# Altium schematic sheet ADC_MCU_LS.SchDoc(ADC_MCU_LS)
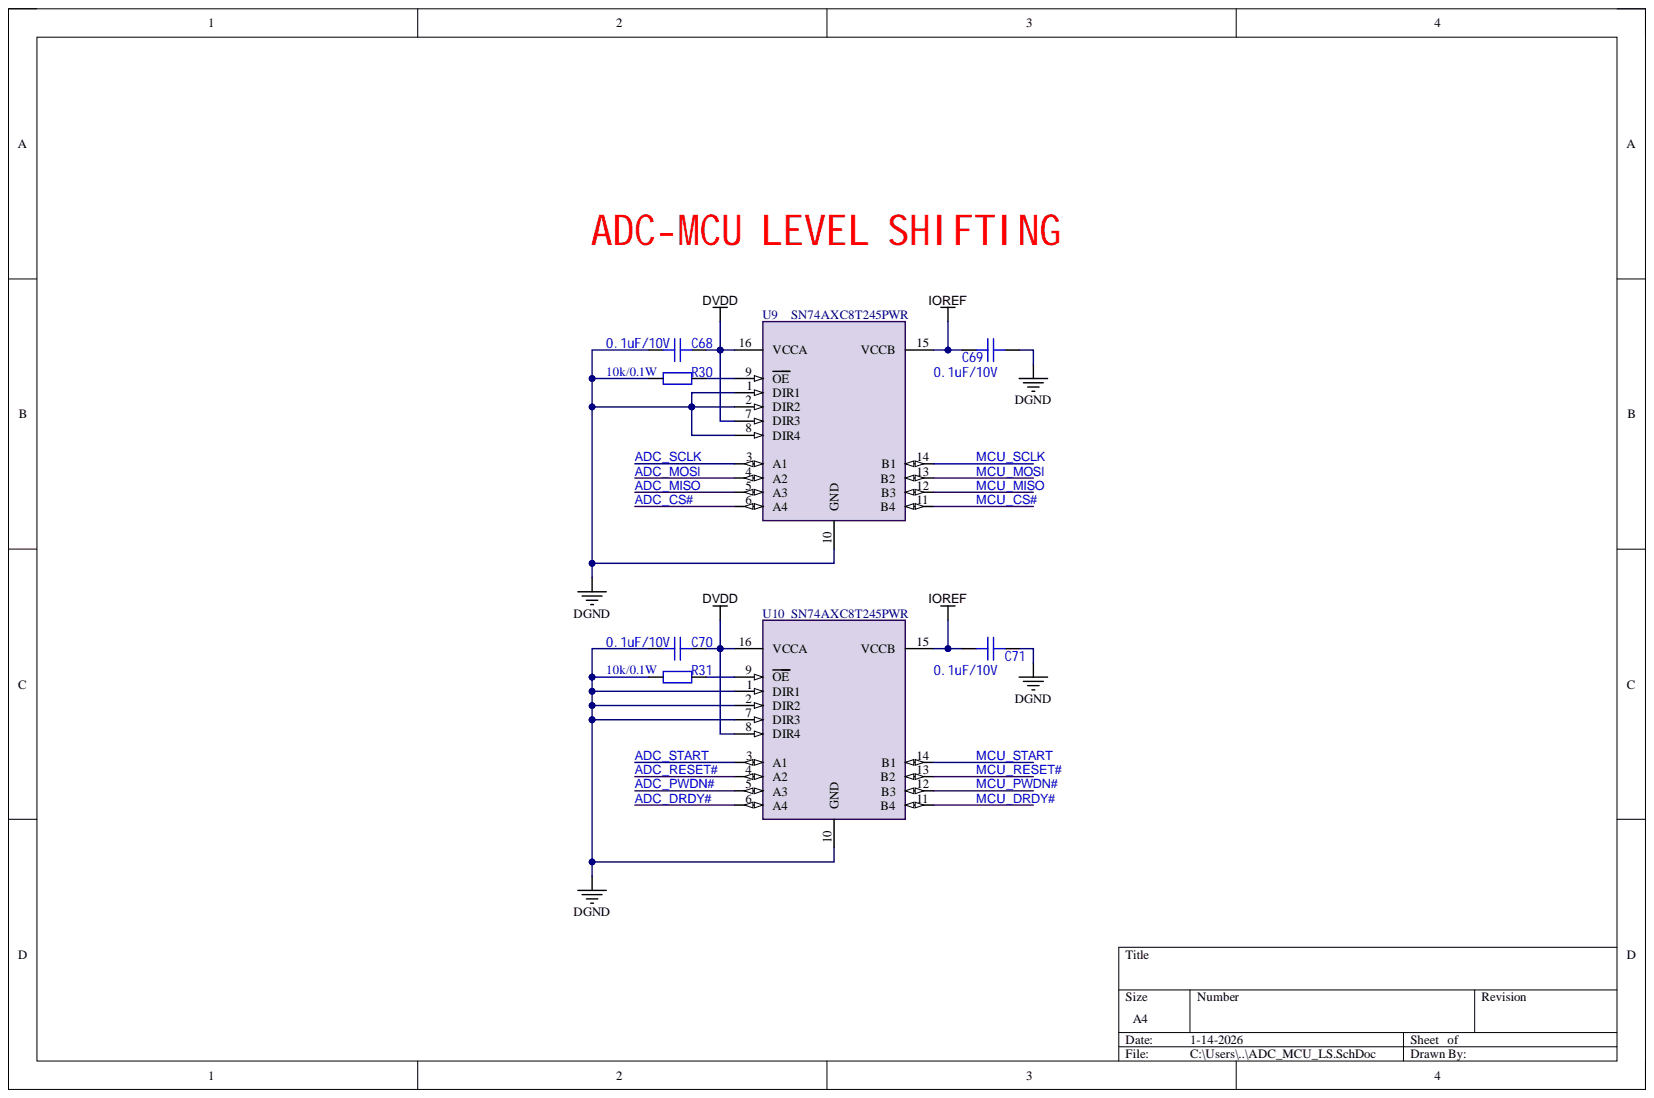
[diagram p0: ADC_MCU_LS.SchDoc]

## Parts
C68  0.1uF  value 0.1uF/10V  [C0603]
C69  0.1uF  value 0.1uF/10V  [C0603]
C70  0.1uF  value 0.1uF/10V  [C0603]
C71  0.1uF  value 0.1uF/10V  [C0603]
R30  value 10k/0.1W  [R0603]  (hidden comment: 10K)
R31  value 10k/0.1W  [R0603]  (hidden comment: 10K)
U9  SN74AXC8T245PWR  [SOP65P640X120-16N]
U10  SN74AXC8T245PWR  [SOP65P640X120-16N]

## Nets
DGND: C68.2 C69.1 C70.2 C71.1 R30.1 R31.1 U9.1(DIR1) U9.2(DIR2) U9.8(DIR4) U9.10(GND) U10.1(DIR1) U10.2(DIR2) U10.7(DIR3) U10.10(GND)
DVDD: C68.1 C70.1 U9.7(DIR3) U9.16(VCCA) U10.8(DIR4) U10.16(VCCA)
IOREF: C69.2 C71.2 U9.15(VCCB) U10.15(VCCB)
ADC_CS#: U9.6(A4)
ADC_DRDY#: U10.6(A4)
ADC_MISO: U9.5(A3)
ADC_MOSI: U9.4(A2)
ADC_PWDN#: U10.5(A3)
ADC_RESET#: U10.4(A2)
ADC_SCLK: U9.3(A1)
ADC_START: U10.3(A1)
MCU_CS#: U9.11(B4)
MCU_DRDY#: U10.11(B4)
MCU_MISO: U9.12(B3)
MCU_MOSI: U9.13(B2)
MCU_PWDN#: U10.12(B3)
MCU_RESET#: U10.13(B2)
MCU_SCLK: U9.14(B1)
MCU_START: U10.14(B1)
NetR30_2: R30.2 U9.9(OE)
NetR31_2: R31.2 U10.9(OE)

## Library data of the parts
C68-C71 = CC0603KRX7R6BB104: CAP CER 0.1UF 10V X7R 0603; Add into BOM: yes; Device: CAP_0603; Component Kind: Standard; ComponentLink1Description: Product link; ComponentLink1URL: https://www.digikey.in/en/products/detail/yageo/CC0603KRX7R6BB104/5883741; Convert to PCB: yes; Library Name: US00001.SCHLIB; Supplier Footprint: 0603; Symbol: CAP
R30 R31 = RC0603JR-0710KL: RES 10K OHM 5% 1/10W 0603; Add into BOM: yes; Device: Res_0603; Component Kind: Standard; ComponentLink1Description: Product link; ComponentLink1URL: https://www.digikey.in/en/products/detail/yageo/RC0603JR-0710KL/726700; Convert to PCB: yes; Library Name: US00001.SCHLIB; Supplier Footprint: 0603; Symbol: Res
U9 U10 = SN74AXC8T245PWR: IC TRANSLATION TXRX 3.6V 24TSSOP; Component Kind: Standard; ComponentLink1Description: Product link; ComponentLink1URL: https://www.digikey.com/en/products/detail/texas-instruments/SN74AXC8T245PWR/8567230?s=N4IgTCBcDaIMoDkDsAWAggDQMIA4AqYKArAAoDqASiALoC%2BQA; Library Name: US00001.SCHLIB
